# Altium schematic sheet Level_Shifter.SchDoc
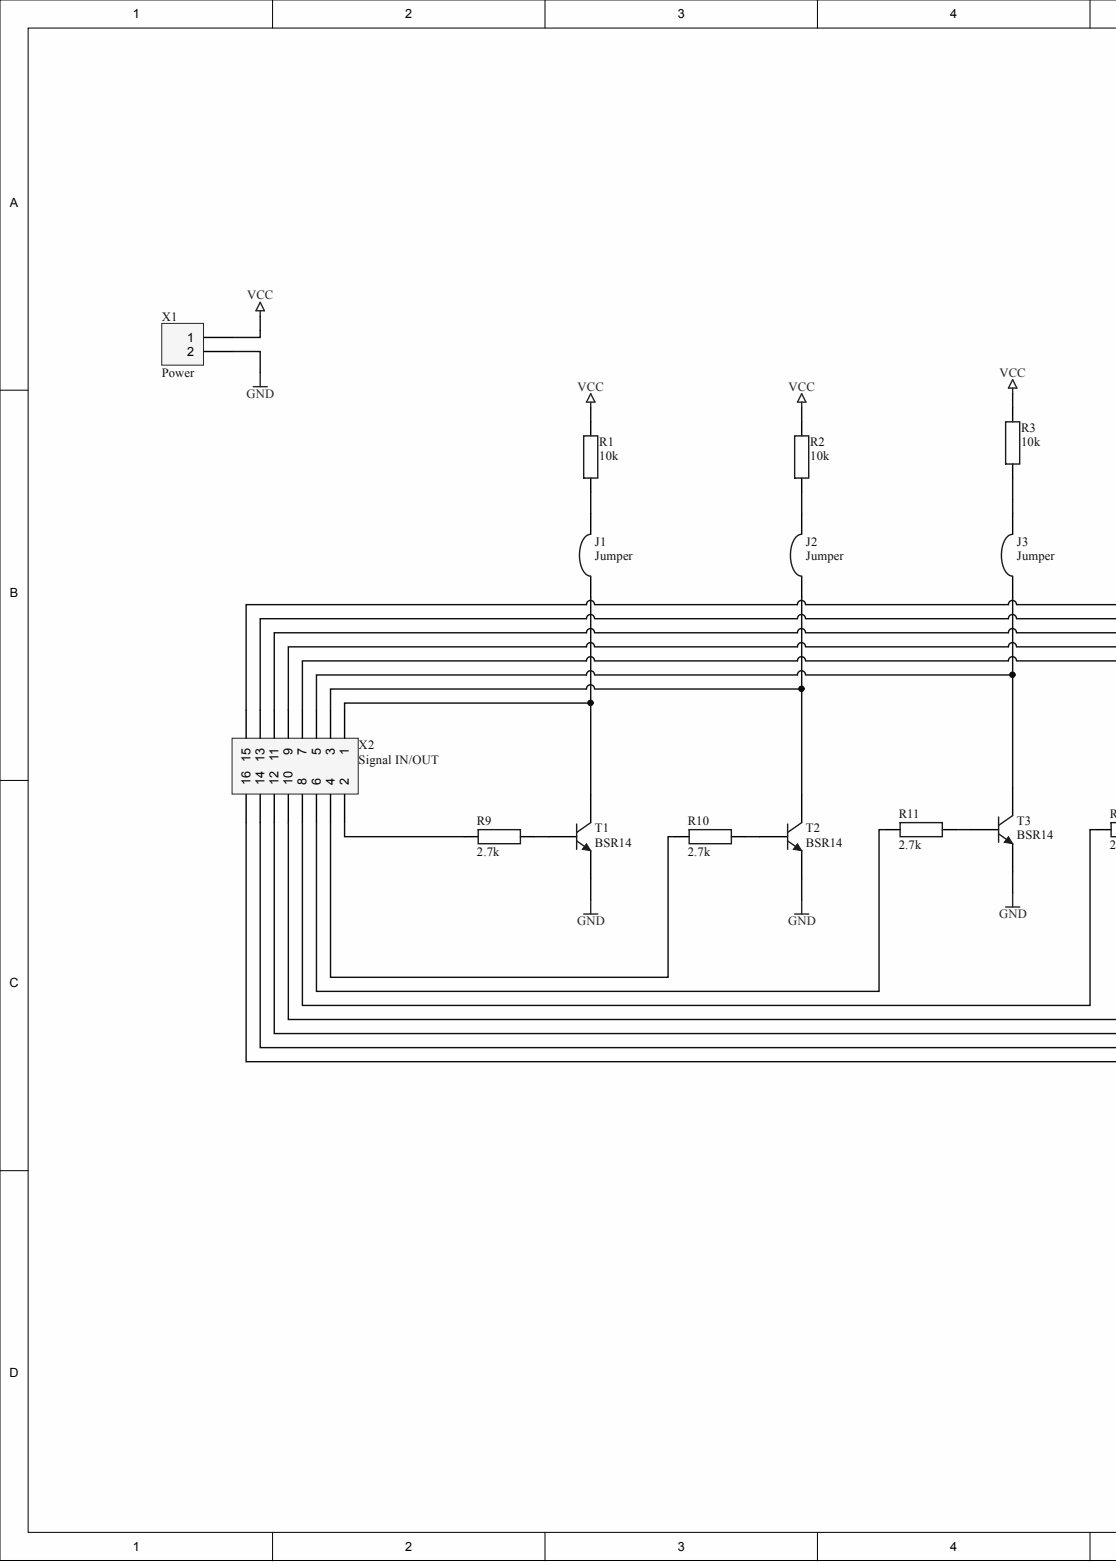
[diagram p0: Level_Shifter.SchDoc, left]
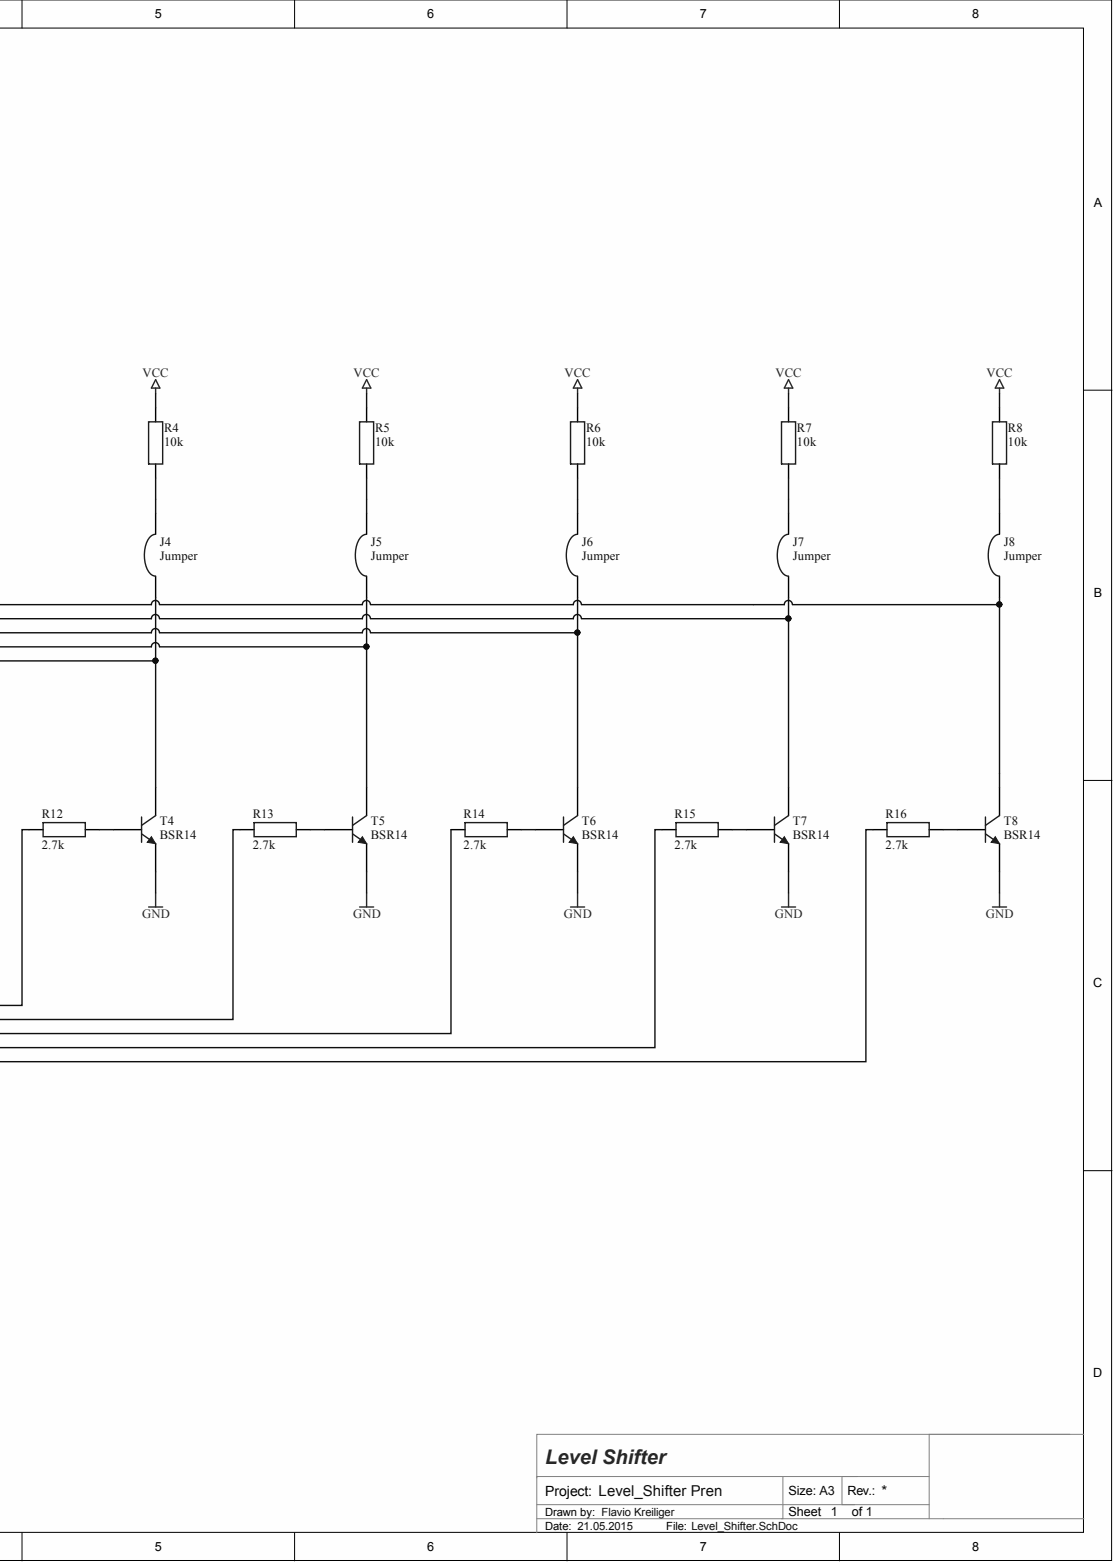
[diagram p1: Level_Shifter.SchDoc, right]

## Parts
J1  Jumper  [Jumper]  (jumper)
J2  Jumper  [Jumper]  (jumper)
J3  Jumper  [Jumper]  (jumper)
J4  Jumper  [Jumper]  (jumper)
J5  Jumper  [Jumper]  (jumper)
J6  Jumper  [Jumper]  (jumper)
J7  Jumper  [Jumper]  (jumper)
J8  Jumper  [Jumper]  (jumper)
R1  10k  [0805]
R2  10k  [0805]
R3  10k  [0805]
R4  10k  [0805]
R5  10k  [0805]
R6  10k  [0805]
R7  10k  [0805]
R8  10k  [0805]
R9  2.7k  [0805]
R10  2.7k  [0805]
R11  2.7k  [0805]
R12  2.7k  [0805]
R13  2.7k  [0805]
R14  2.7k  [0805]
R15  2.7k  [0805]
R16  2.7k  [0805]
T1  BSR14  [SOT-23A_M]
T2  BSR14  [SOT-23A_M]
T3  BSR14  [SOT-23A_M]
T4  BSR14  [SOT-23A_M]
T5  BSR14  [SOT-23A_M]
T6  BSR14  [SOT-23A_M]
T7  BSR14  [SOT-23A_M]
T8  BSR14  [SOT-23A_M]
X1  Power  [HDR1X2]
X2  Signal IN/OUT  [HDR2X8]

## Nets
GND: T1.2 T2.2 T3.2 T4.2 T5.2 T6.2 T7.2 T8.2 X1.2
VCC: R1.2 R2.2 R3.2 R4.2 R5.2 R6.2 R7.2 R8.2 X1.1
NetJ1_1: J1.1 T1.3 X2.1
NetJ1_2: J1.2 R1.1
NetJ2_1: J2.1 T2.3 X2.3
NetJ2_2: J2.2 R2.1
NetJ3_1: J3.1 T3.3 X2.5
NetJ3_2: J3.2 R3.1
NetJ4_1: J4.1 T4.3 X2.7
NetJ4_2: J4.2 R4.1
NetJ5_1: J5.1 T5.3 X2.9
NetJ5_2: J5.2 R5.1
NetJ6_1: J6.1 T6.3 X2.11
NetJ6_2: J6.2 R6.1
NetJ7_1: J7.1 T7.3 X2.13
NetJ7_2: J7.2 R7.1
NetJ8_1: J8.1 T8.3 X2.15
NetJ8_2: J8.2 R8.1
NetR9_1: R9.1 X2.2
NetR9_2: R9.2 T1.1
NetR10_1: R10.1 X2.4
NetR10_2: R10.2 T2.1
NetR11_1: R11.1 X2.6
NetR11_2: R11.2 T3.1
NetR12_1: R12.1 X2.8
NetR12_2: R12.2 T4.1
NetR13_1: R13.1 X2.10
NetR13_2: R13.2 T5.1
NetR14_1: R14.1 X2.12
NetR14_2: R14.2 T6.1
NetR15_1: R15.1 X2.14
NetR15_2: R15.2 T7.1
NetR16_1: R16.1 X2.16
NetR16_2: R16.2 T8.1

## Library data of the parts
J1-J8 = Jumper: Wire link; Library Name: Level_shifter.SchLib
R1-R16 = R?: Normaler Widerstand; Component Kind: Standard; Library Name: DEVICE_HSLU.SchLib
T1-T8 = BC817-25: NPN General Purpose Amplifier; Component Kind: Standard; ComponentLink1Description: Manufacturer Link; ComponentLink1URL: http://www.fairchildsemi.com/; ComponentLink2Description: Discontinuation Notice; ComponentLink2URL: http://www.fairchildsemi.com/obsolete/obs.jsp; DatasheetVersion: 1997; LatestRevisionDate: 20-Nov-2009; LatestRevisionNote: IPC-7351 Footprint Added.; Library Name: FSC Discrete BJT.IntLib; PackageDescription: 3-Pin SOT-23 Package 0.95 mm Pitch, 2.3 mm Lead Span; PackageReference: SOT-23A; PackageVersion: Sep-1998; Published: 8-Jun-2000; Publisher: Altium Limited
X1 = Header 2: Header, 2-Pin; Component Kind: Standard; LatestRevisionDate: 17-Jul-2002; LatestRevisionNote: Re-released for DXP Platform.; Library Name: Miscellaneous Connectors.IntLib; Signal Integrity: Connector; Publisher: Altium Limited
X2 = Header 8X2: Header, 8-Pin, Dual row; Component Kind: Standard; LatestRevisionDate: 17-Jul-2002; LatestRevisionNote: Re-released for DXP Platform.; Library Name: Miscellaneous Connectors.IntLib; Signal Integrity: Connector; Publisher: Altium Limited
